# Altium schematic sheet Downstream.SchDoc
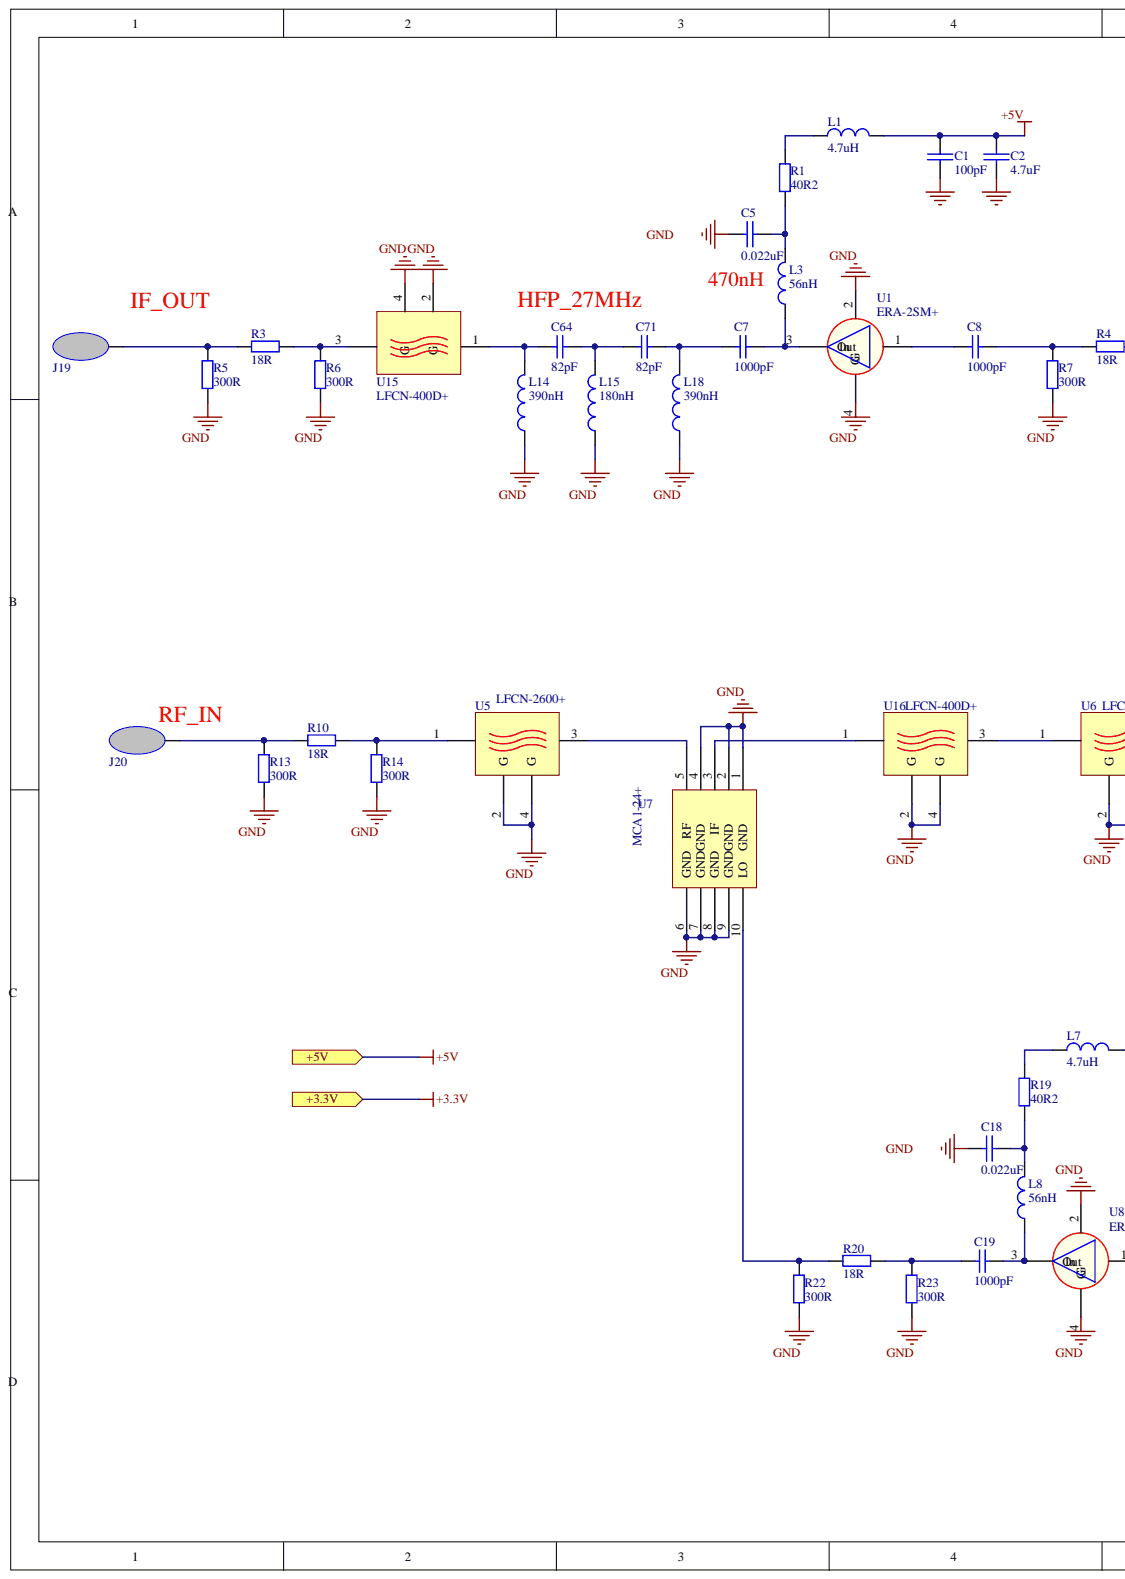
[diagram p0: Downstream.SchDoc, left]
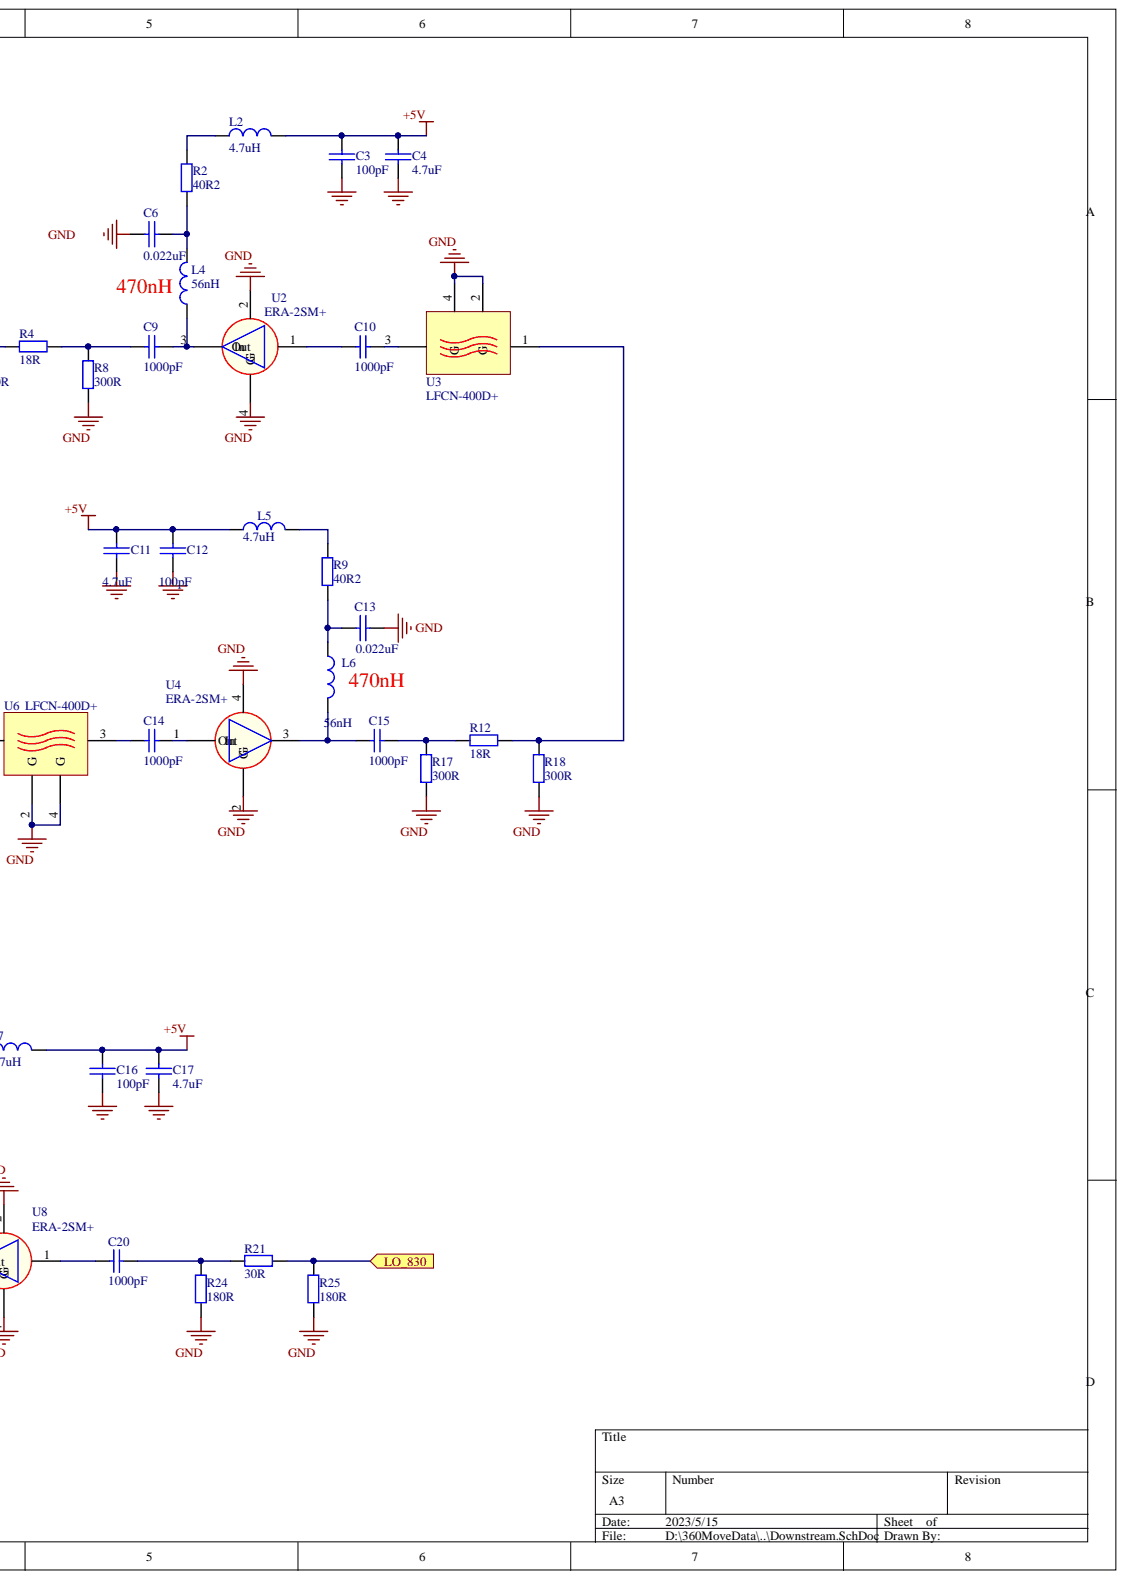
[diagram p1: Downstream.SchDoc, right]

## Parts
C1  value 100pF  [C0402]  (hidden comment: J-CC41-0402CG101J500NT)
C2  value 4.7uF  [C0402]  (hidden comment: J-CT41-0402X475M6R3NT)
C3  value 100pF  [C0402]  (hidden comment: J-CC41-0402CG101J500NT)
C4  value 4.7uF  [C0402]  (hidden comment: J-CT41-0402X475M6R3NT)
C5  value 0.022uF  [C0402]  (hidden comment: J-CT41-0402B223K500NT)
C6  value 0.022uF  [C0402]  (hidden comment: J-CT41-0402B223K500NT)
C7  value 1000pF  [C0402]  (hidden comment: J-CT41-0402B102K500NT)
C8  value 1000pF  [C0402]  (hidden comment: J-CT41-0402B102K500NT)
C9  value 1000pF  [C0402]  (hidden comment: J-CT41-0402B102K500NT)
C10  value 1000pF  [C0402]  (hidden comment: J-CT41-0402B102K500NT)
C11  value 4.7uF  [C0402]  (hidden comment: J-CT41-0402X475M6R3NT)
C12  value 100pF  [C0402]  (hidden comment: J-CC41-0402CG101J500NT)
C13  value 0.022uF  [C0402]  (hidden comment: J-CT41-0402B223K500NT)
C14  value 1000pF  [C0402]  (hidden comment: J-CT41-0402B102K500NT)
C15  value 1000pF  [C0402]  (hidden comment: J-CT41-0402B102K500NT)
C16  value 100pF  [C0402]  (hidden comment: J-CC41-0402CG101J500NT)
C17  value 4.7uF  [C0402]  (hidden comment: J-CT41-0402X475M6R3NT)
C18  value 0.022uF  [C0402]  (hidden comment: J-CT41-0402B223K500NT)
C19  value 1000pF  [C0402]  (hidden comment: J-CT41-0402B102K500NT)
C20  value 1000pF  [C0402]  (hidden comment: J-CT41-0402B102K500NT)
C64  value 82pF  [C0603]  (hidden comment: CC41-0603CG820J500NT)
C71  value 82pF  [C0603]  (hidden comment: CC41-0603CG820J500NT)
J19  Pad  [pad(2*0.8)]  (value not drawn)
J20  Pad  [pad(2*0.8)]  (value not drawn)
L1  value 4.7uH  [L0805]  (hidden comment: 0805AF-472XJRB)
L2  value 4.7uH  [L0805]  (hidden comment: 0805AF-472XJRB)
L3  value 56nH  [L0603]  (hidden comment: J-MLCH1608H56NJ)
L4  value 56nH  [L0603]  (hidden comment: J-MLCH1608H56NJ)
L5  value 4.7uH  [L0805]  (hidden comment: 0805AF-472XJRB)
L6  value 56nH  [L0603]  (hidden comment: J-MLCH1608H56NJ)
L7  value 4.7uH  [L0805]  (hidden comment: 0805AF-472XJRB)
L8  value 56nH  [L0603]  (hidden comment: J-MLCH1608H56NJ)
L14  value 390nH  [L0603]  (hidden comment: BKW0603UCR39JGT 二筛)
L15  value 180nH  [L0603]  (hidden comment: 0603CS-R18XJEU)
L18  value 390nH  [L0603]  (hidden comment: BKW0603UCR39JGT 二筛)
R1  value 40R2  [R0402]  (hidden comment: J-RC02W40R2FT)
R2  value 40R2  [R0402]  (hidden comment: J-RC02W40R2FT)
R3  value 18R  [R0402]  (hidden comment: J-RC02W180JT)
R4  value 18R  [R0402]  (hidden comment: J-RC02W180JT)
R5  value 300R  [R0402]  (hidden comment: J-RC02W301FT)
R6  value 300R  [R0402]  (hidden comment: J-RC02W301FT)
R7  value 300R  [R0402]  (hidden comment: J-RC02W301FT)
R8  value 300R  [R0402]  (hidden comment: J-RC02W301FT)
R9  value 40R2  [R0402]  (hidden comment: J-RC02W40R2FT)
R10  value 18R  [R0402]  (hidden comment: J-RC02W180JT)
R12  value 18R  [R0402]  (hidden comment: J-RC02W180JT)
R13  value 300R  [R0402]  (hidden comment: J-RC02W301FT)
R14  value 300R  [R0402]  (hidden comment: J-RC02W301FT)
R17  value 300R  [R0402]  (hidden comment: J-RC02W301FT)
R18  value 300R  [R0402]  (hidden comment: J-RC02W301FT)
R19  value 40R2  [R0402]  (hidden comment: J-RC02W40R2FT)
R20  value 18R  [R0402]  (hidden comment: J-RC02W180JT)
R21  value 30R  [R0402]  (hidden comment: J-RC02W331JT)
R22  value 300R  [R0402]  (hidden comment: J-RC02W301FT)
R23  value 300R  [R0402]  (hidden comment: J-RC02W301FT)
R24  value 180R  [R0402]  (hidden comment: J-RC02W181FT)
R25  value 180R  [R0402]  (hidden comment: J-RC02W181FT)
U1  ERA-2SM+  [WW107_V1.0]
U2  ERA-2SM+  [WW107_V1.0]
U3  LFCN-400D+  [FV1206_V1.0]
U4  ERA-2SM+  [WW107_V1.0]
U5  LFCN-2600+  [FV1206_V1.0]
U6  LFCN-400D+  [FV1206_V1.0]
U7  MCA1-24+  [MCA1-24+]
U8  ERA-2SM+  [WW107_V1.0]
U15  LFCN-400D+  [FV1206_V1.0]
U16  LFCN-400D+  [FV1206_V1.0]

## Ports
+3.3V, +5V, LO_830

## Nets
GND: C1.2 C2.2 C3.2 C4.2 C5.1 C6.1 C11.2 C12.2 C13.1 C16.2 C17.2 C18.1 L14.1 L15.1 L18.1 R5.2 R6.1 R7.2 R8.1 R13.2 R14.1 R17.2 R18.1 R22.2 R23.1 R24.2 R25.1 U1.2 U1.4(G) U2.2 U2.4(G) U3.2 U3.4 U4.2(G) U4.4 U5.2(G) U5.4(G) U6.2(G) U6.4(G) U7.1 U7.2 U7.4 U7.6(GND) U7.7 U7.8(GND) U7.9 U8.2 U8.4(G) U15.2 U15.4 U16.2(G) U16.4(G)
+5V: C1.1 C2.1 C3.1 C4.1 C11.1 C12.1 C16.1 C17.1 L1.2 L2.2 L5.2 L7.2 ; port +5V
LO_830: R21.2 R25.2 ; port LO_830
NetC5_2: C5.2 L3.1 R1.1
NetC6_2: C6.2 L4.1 R2.1
NetC7_1: C7.1 C71.2 L18.2
NetC7_2: C7.2 L3.2 U1.3(Out)
NetC8_1: C8.1 U1.1
NetC8_2: C8.2 R4.1 R7.1
NetC9_1: C9.1 R4.2 R8.2
NetC9_2: C9.2 L4.2 U2.3(Out)
NetC10_1: C10.1 U2.1
NetC10_2: C10.2 U3.3
NetC13_2: C13.2 L6.1 R9.1
NetC14_1: C14.1 U6.3
NetC14_2: C14.2 U4.1(Out)
NetC15_1: C15.1 L6.2 U4.3
NetC15_2: C15.2 R12.1 R17.1
NetC18_2: C18.2 L8.1 R19.1
NetC19_1: C19.1 R20.2 R23.2
NetC19_2: C19.2 L8.2 U8.3(Out)
NetC20_1: C20.1 U8.1
NetC20_2: C20.2 R21.1 R24.1
NetC64_1: C64.1 L14.2 U15.1
NetC64_2: C64.2 C71.1 L15.2
NetJ19_1: J19.1 R3.1 R5.1
NetJ20_1: J20.1 R10.1 R13.1
NetL1_1: L1.1 R1.2
NetL2_1: L2.1 R2.2
NetL5_1: L5.1 R9.2
NetL7_1: L7.1 R19.2
NetR3_2: R3.2 R6.2 U15.3
NetR10_2: R10.2 R14.2 U5.1
NetR12_2: R12.2 R18.2 U3.1
NetR20_1: R20.1 R22.1 U7.10(LO)
NetU5_3: U5.3 U7.5
NetU6_1: U6.1 U16.3
NetU7_3: U7.3 U16.1
+3.3V: ; port +3.3V

## Library data of the parts
C1-C6 C11-C13 C16-C18 = CAP: Component Kind: Standard
C7-C10 C14 C15 C19 C20 C64 C71 = CAP-V1.0: Capacitor; Component Kind: Standard; LatestRevisionDate: 17-Jul-2002; LatestRevisionNote: Re-released for DXP Platform.; PackageReference: RAD-0.3; Published: 8-Jun-2000; Library Name: 2.4GHz_Downstream.SchLib; Publisher: Altium Limited
L1-L8 = INDUCTOR1: Component Kind: Standard
L14 L15 L18 = Inductor-V1.0: Inductor; Component Kind: Standard; Version: 1.0; Library Name: 2.4GHz_Downstream.SchLib
R1-R10 R12-R14 R17-R25 = Res: Resistor; Component Kind: Standard; Library Name: 2.4GHz_Downstream.SchLib
U1 U2 U4 U8 = ERA-2SM+: F:DC—6G;G:16.4dB@100MHz;P-1dB:13dBm;NF:3.7dB;供电:3.3V; Component Kind: Standard; Library Name: 2.4GHz_Downstream.SchLib
U3 U6 U15 U16 = LFCN-400D+: LPF,Fp:<400MHz; Component Kind: Standard
  U3 U6 U16: Library Name: 2.4GHz_Downstream.SchLib
  U15: Library Name: NT-M4234-04 REV1.0.SchLib
U5 = LFCN-2600+: LPF,Fp:<2600MHz; Component Kind: Standard; Library Name: 2.4GHz_Downstream.SchLib
U7 = MCA1-24+: RF/LO:300-2400MHz IF:DC-700MHz CL:6.1dB; Component Kind: Standard; Library Name: 2.4GHz_Downstream.SchLib
J19 J20 = Pad: Component Kind: Standard; Library Name: 2.4GHz_Downstream.SchLib
